# Altium schematic sheet Power.SchDoc
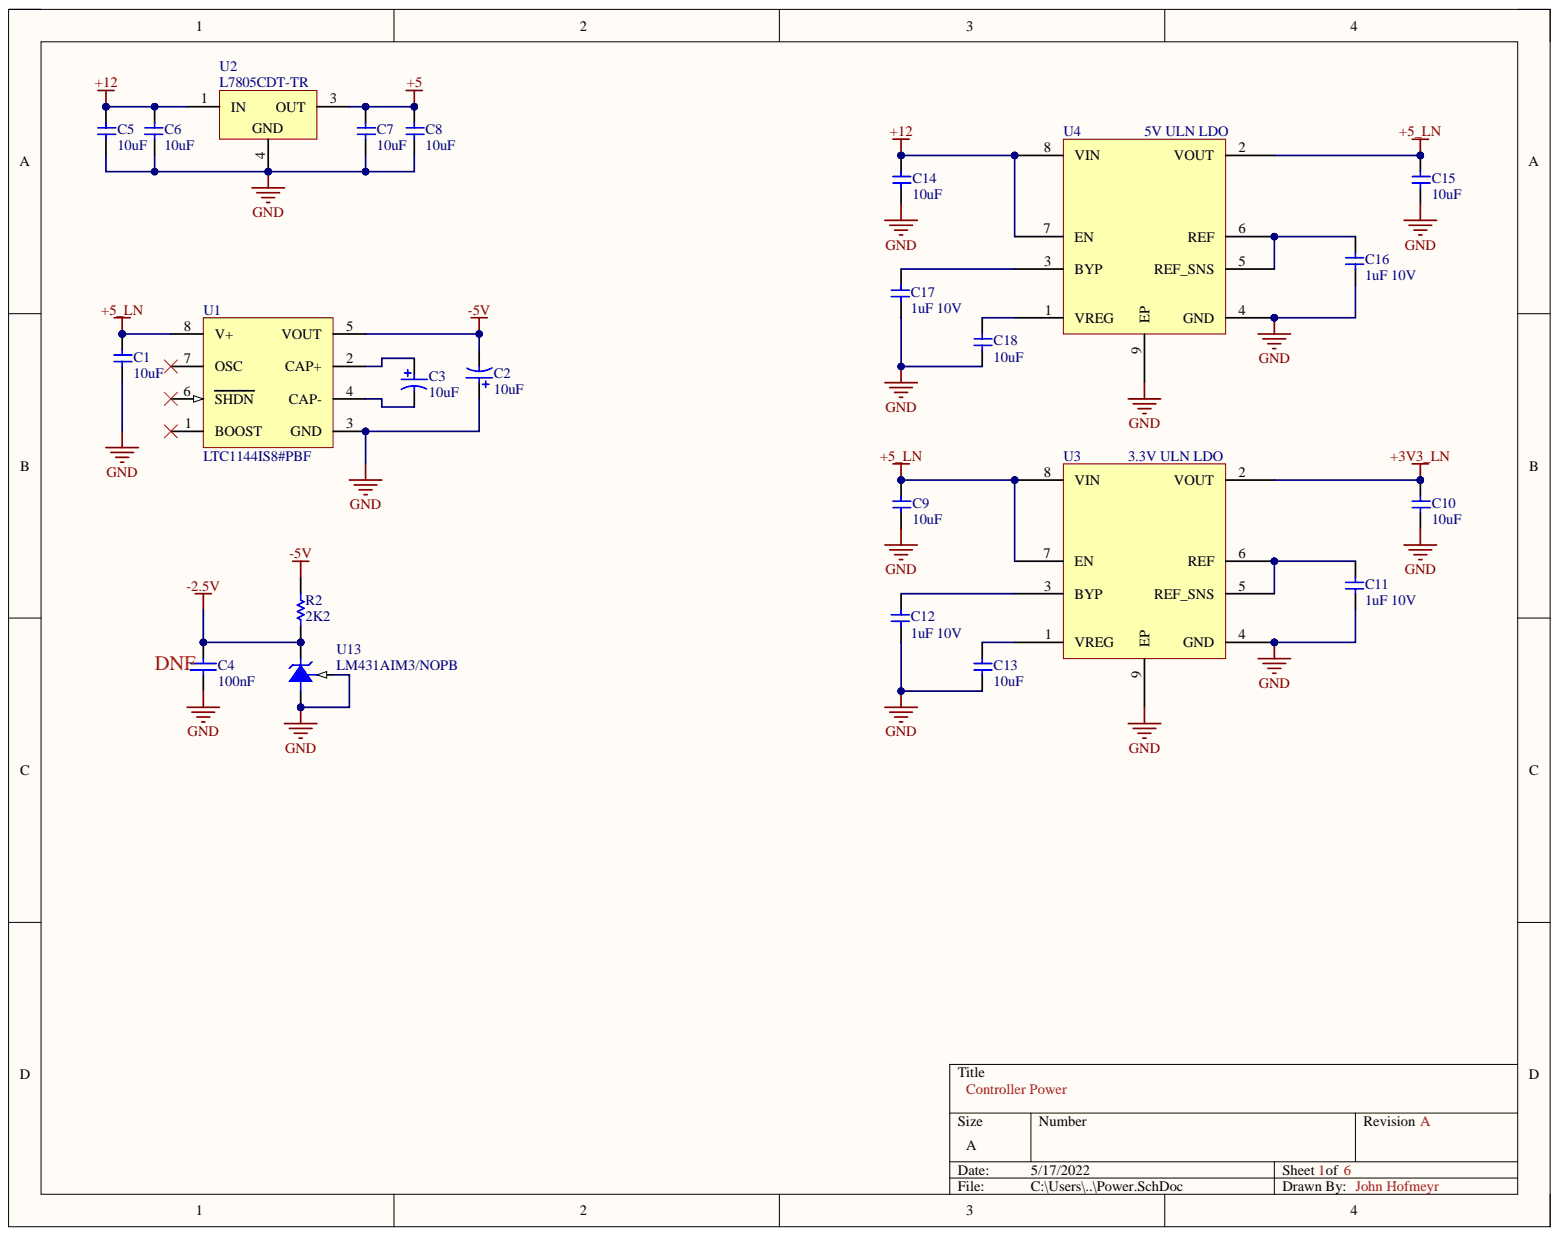
[diagram p0: Power.SchDoc]

## Parts
C1  10uF  [C0805]
C2  10uF  [NIC-UVR-5x11-2_V]
C3  10uF  [NIC-UVR-5x11-2_V]
C4  100nF  [CAPC2013X140X50ML20T25]
C5  10uF  [C0805]
C6  10uF  [C0805]
C7  10uF  [C0805]
C8  10uF  [C0805]
C9  10uF  [C0805]
C10  10uF  [C0805]
C11  1uF 10V  [C0805]
C12  1uF 10V  [C0805]
C13  10uF  [C0805]
C14  10uF  [C0805]
C15  10uF  [C0805]
C16  1uF 10V  [C0805]
C17  1uF 10V  [C0805]
C18  10uF  [C0805]
R2  2K2  [RESC2013X50X40LL20T20]
U1  LTC1144IS8#PBF  [LT-S8-8_L]
U2  L7805CDT-TR  [TO-252_N]
U3  3.3V ULN LDO  Nominal Output Voltage: 3.3V  [8-SOIC-HS]
U4  5V ULN LDO  Nominal Output Voltage: 5V  [8-SOIC-HS]
U13  LM431AIM3/NOPB  [DBZ0003A_L]

## Nets
+3V3_LN: C10.1 U3.2(VOUT)
+5: C7.1 C8.1 U2.3
+5_LN: C1.1 C9.1 C15.1 U1.8(V+) U3.7(EN) U3.8(VIN) U4.2(VOUT)
+12: C5.1 C6.2 C14.1 U2.1 U4.7(EN) U4.8(VIN)
-2.5V: C4.2 R2.1 U13.1
-5V: C2.2 R2.2 U1.5(VOUT)
GND: C1.2 C2.1 C4.1 C5.2 C6.1 C7.2 C8.2 C9.2 C10.2 C11.1 C12.1 C13.2 C14.2 C15.2 C16.1 C17.1 C18.2 U1.3(GND) U2.4 U3.4(GND) U3.9(EP) U4.4(GND) U4.9(EP) U13.2 U13.3
NetC3_1: C3.1 U1.2(CAP+)
NetC3_2: C3.2 U1.4(CAP-)
NetC11_2: C11.2 U3.5(REF_SNS) U3.6(REF)
NetC12_2: C12.2 U3.3(BYP)
NetC13_1: C13.1 U3.1(VREG)
NetC16_2: C16.2 U4.5(REF_SNS) U4.6(REF)
NetC17_2: C17.2 U4.3(BYP)
NetC18_1: C18.1 U4.1(VREG)

Not connected: U1 1(BOOST) 6(SHDN) 7(OSC)

## Library data of the parts
C1 C5-C10 C13-C15 C18 = GRM21BR61E106KA73K: CAP CER 10UF 25V X5R 0805; Manufacturer: Murata; Tolerance: 10%; Capacitance: 10uF; Case/Package: 0805; Category: Ceramic Capacitors; Component Kind: Standard; ComponentLink1Description: http://www.mouser.com/ds/2/281/c02e-2905.pdf?src-supplier=Mouser; ComponentLink1URL: http://www.mouser.com/ds/2/281/c02e-2905.pdf?src-supplier=Mouser; ComponentLink2Description: http://search.murata.co.jp/Ceramy/image/img/A01X/G101/ENG/GRM21BR61E106KA73-01.pdf?src-supplier=Digi-Key; ComponentLink2URL: http://search.murata.co.jp/Ceramy/image/img/A01X/G101/ENG/GRM21BR61E106KA73-01.pdf?src-supplier=Digi-Key; ComponentLink3Description: https://search.murata.co.jp/Ceramy/image/img/A01X/G101/ENG/GRM21BR61E106KA73-01.pdf?src-supplier=Digi-Key; ComponentLink3URL: https://search.murata.co.jp/Ceramy/image/img/A01X/G101/ENG/GRM21BR61E106KA73-01.pdf?src-supplier=Digi-Key; Dielectric: X5R; Length: 0.079inch; Library Name: DCLoadV2.SchLib; Manufacturer Part Number: GRM21BR61E106KA73K; Max Operating Temperature: 85°C; Min Operating Temperature: -55°C; Mount: Surface Mount; Package Quantity: 10000; Packaging: Tape and Reel; Pricing 1: 1=0.25000, 10=0.17200, 50=0.10340, 100=0.08740, 500=0.05978, 1000=0.05288, 2500=0.05058, 5000=0.04598 (USD); RoHS: Yes; Stock 1: 51629; Supplier 1: Digi-Key; Supplier Part Number 1: 490-16824-1-ND; Thickness: 0.055inch; Voltage Rating: 25V; Voltage Rating (DC): 25V; Weight: 0.000335oz; Width: 0.049inch
C2 C3 = CMP-1217-00002-1: Aluminum Electrolytic Capacitor, 47 uF +/-20%, 35 V, -40 to 85 degC, 2-Pin THD, RoHS, Bulk; Manufacturer: Nichicon; PartNumber: UVR1V470MDD; Rated Capacitance: 47 uF; Rated Ripple: 130 mA; Rated Voltage: 35 V; Capacitance Tolerance: +/-20%; Component Kind: Standard; ComponentLink1Description: Manufacturer URL; ComponentLink1URL: http://www.nichicon.co.jp/; ComponentLink2Description: Datasheet; ComponentLink2URL: http://nichicon-us.com/english/products/pdfs/e-vr.pdf; ComponentLink3Description: Package Specification; ComponentLink3URL: http://nichicon-us.com/english/products/pdfs/e-vr.pdf; DatasheetVersion: Sep-13; Library Name: Altium Content Vault; Mounting Technology: Through Hole; PackageDescription: 2-Pin Through Hole Device, Dia 5 mm, Body Length 11 mm, Pin Spacing 2 mm; Packing: Bulk; RoHS: TRUE; Temperature Range: -40 to 85 degC
C4 = CMP-2007-04689-1: Manufacturer: AVX Interconnect / Elco; Part Number: 08055D104KAT2A; Component Kind: Standard; Library Name: Altium Content Vault
C11 C12 C16 C17 = LMK212B7105KGHTR: Manufacturer: Taiyo Yuden; Component Kind: Standard; Library Name: DCLoadV2.SchLib; Manufacturer Part Number: LMK212B7105KGHTR; Pricing 1: 1=0.20000, 10=0.14000, 50=0.07940, 100=0.06580, 500=0.04614, 1000=0.03820 (USD); RoHS: No; Stock 1: 2600; Supplier 1: Digi-Key; Supplier Part Number 1: 587-6338-1-ND
R2 = CMP-2001-03322-1: Manufacturer: Susumu; Part Number: RR1220P-222-D; Component Kind: Standard; Library Name: Altium Content Vault
U1 = CMP-0475-00562-1: Switched-Capacitor Wide Input Range Voltage Converter with Shutdown, 8-pin SOIC (S8-8), -40 to 85 degC, Pb-Free; Manufacturer: Linear Technology; Frequency(kHz): 10; Ishutdown(uA): 8; Isupply(mA): 1.1; Max Iout(mA): 50; Vin Max(V): 18; Vin Min(V): 2; Voutput: 2Vin, -Vin, 0.5Vin; Component Kind: Standard; ComponentLink1Description: Manufacturer URL; ComponentLink1URL: http://www.linear.com/; ComponentLink2Description: Datasheet; ComponentLink2URL: http://cds.linear.com/docs/en/datasheet/lt1144.pdf; ComponentLink3Description: Package Specification; ComponentLink3URL: http://cds.linear.com/docs/en/packaging/05081610_G_SO8.pdf; Library Name: Altium Content Vault; Mounting Technology: Surface Mount; PackageDescription: 8-Pin Plastic Small Outline (Narrow .150 Inch), Body 4.9 x 3.9 mm, Pitch 1.27 mm; PackageReference: S8-8; PackageVersion: Rev. G, 03/2012; PartNumber: LTC1144IS8#PBF; RoHS: TRUE
U2 = CMP-0244-00216-1: Positive Voltage Regulator, 3-Pin DPAK, Tape and Reel; Component Kind: Standard; ComponentLink1Description: Manufacturer URL; ComponentLink1URL: http://www.st.com; ComponentLink2Description: Datasheet; ComponentLink2URL: http://www.st.com/internet/com/TECHNICAL_RESOURCES/TECHNICAL_LITERATURE/DATASHEET/CD00000444.pdf; DatasheetVersion: 16/11/2011; Library Name: Altium Content Vault; PackageDescription: 3-Pin Transistor Outline Package 2.28 mm Pitch, 9.7 mm Lead Span (DPAK); PackageReference: TO-252; PackageVersion: 2011
U3 = ADM7154ACPZ-3.3-R7: IC REG LINEAR 3.3V 600MA 8LFCSP; Manufacturer: Analog Devices; Accuracy: 0.5%; Dropout Voltage: 120mV; Max Input Voltage: 5.5V; Min Current Limit: 700mA; Min Input Voltage: 2.3V; Number of Outputs: 1; Output Type: Fixed; Polarity: Positive; Quiescent Current: 4mA; Category: Voltage Regulators - Linear; Component Kind: Standard; ComponentLink1Description: http://www.mouser.com/ds/2/609/ADM7154-534206.pdf?src-supplier=Mouser; ComponentLink1URL: http://www.mouser.com/ds/2/609/ADM7154-534206.pdf?src-supplier=Mouser; ComponentLink2Description: http://www.farnell.com/datasheets/2256404.pdf?src-supplier=Farnell; ComponentLink2URL: http://www.farnell.com/datasheets/2256404.pdf?src-supplier=Farnell; ComponentLink3Description: http://www.farnell.com/datasheets/2256404.pdf?src-supplier=Newark; ComponentLink3URL: http://www.farnell.com/datasheets/2256404.pdf?src-supplier=Newark; ComponentLink4Description: http://www.analog.com/static/imported-files/data_sheets/ADM7154.pdf?src-supplier=Avnet; ComponentLink4URL: http://www.analog.com/static/imported-files/data_sheets/ADM7154.pdf?src-supplier=Avnet; ComponentLink5Description: https://www.analog.com/media/en/technical-documentation/data-sheets/ADM7154.pdf?src-supplier=Digi-Key; ComponentLink5URL: https://www.analog.com/media/en/technical-documentation/data-sheets/ADM7154.pdf?src-supplier=Digi-Key; ComponentLink6Description: http://www.analog.com/media/en/technical-documentation/data-sheets/ADM7154.pdf?src-supplier=Digi-Key; ComponentLink6URL: http://www.analog.com/media/en/technical-documentation/data-sheets/ADM7154.pdf?src-supplier=Digi-Key; ComponentLink7Description: http://www.farnell.com/datasheets/2146723.pdf?src-supplier=Element14; ComponentLink7URL: http://www.farnell.com/datasheets/2146723.pdf?src-supplier=Element14; Contact Plating: Tin,Matte; Lead Free: Contains Lead; Library Name: DCLoadV2.SchLib; Manufacturer Part Number: ADM7154ACPZ-3.3-R7; Max Operating Temperature: 125°C; Max Output Current: 600mA; Min Operating Temperature: -40°C; Mount: Surface Mount; Number of Pins: 8; Number of Regulators: 1; Output Current: 600mA; Output Voltage: 3.3V; Packaging: Cut Tape; Pricing 1: 1=5.67000, 10=5.09300, 25=4.81520, 100=3.95900, 250=3.55240, 500=3.42400 (USD); REACH SVHC: No SVHC; RoHS: Yes; Stock 1: 3807; Supplier 1: Digi-Key; Supplier Part Number 1: ADM7154ACPZ-3.3-R7CT-ND
U4 = ADM7150ACPZ-5.0-R7: 800mA ULN LDO 5.0Vo, REEL7; Manufacturer: Analog Devices; Accuracy: 1%; Dropout Voltage: 600mV; Max Input Voltage: 16V; Min Current Limit: 1A; Min Input Voltage: 4.5V; Number of Outputs: 1; Operating Supply Current: 8.6mA; Output Type: Fixed; Polarity: Positive; Power Supply Rejection Ratio (PSRR): -86dB; Quiescent Current: 4.3mA; Category: Voltage Regulators - Linear; Component Kind: Standard; ComponentLink1Description: https://datasheet.ciiva.com/30016/adm7150-30016711.pdf?src-supplier=Avnet; ComponentLink1URL: https://datasheet.ciiva.com/30016/adm7150-30016711.pdf?src-supplier=Avnet; Contact Plating: Tin,Matte; Lead Free: Contains Lead; Library Name: DCLoadV2.SchLib; Manufacturer Part Number: ADM7150ACPZ-5.0-R7; Max Operating Temperature: 125°C; Max Output Current: 800mA; Min Operating Temperature: -40°C; Mount: Surface Mount; Number of Pins: 8; Number of Regulators: 1; Output Current: 800mA; Output Voltage: 5V; Package Quantity: 1500; Packaging: Tape and Reel; Pricing 1: 1=8.66000, 10=7.82600, 25=7.46200, 100=6.18800, 250=5.64200, 500=5.27800 (USD); REACH SVHC: No SVHC; RoHS: Yes; Stock 1: 6315; Supplier 1: Digi-Key; Supplier Part Number 1: ADM7150ACPZ-5.0-R7CT-ND
U13 = CMP-0063-01146-3: Adjustable Precision Zener Shunt Regulator, 3-pin SOT-23, Pb-Free; Manufacturer: Texas Instruments; CompType: MiscPower; PackageTopMarking: N1E*XTT; PowerWise: N; Component Kind: Standard; ComponentLink1Description: Datasheet URL; ComponentLink1URL: http://www.ti.com/general/docs/lit/getliterature.tsp?genericPartNumber=LM431&fileType=pdf; Library Name: Altium Content Vault; PackageDescription: Molded SOT-23, .115 x .051 x .037in Body, 3 Lead, .075 in Pitch; PackageReference: DBZ0003A; PinCount: 3; RoHS: Y
